# Altium schematic sheet GPS-GPRS Module.SchDoc
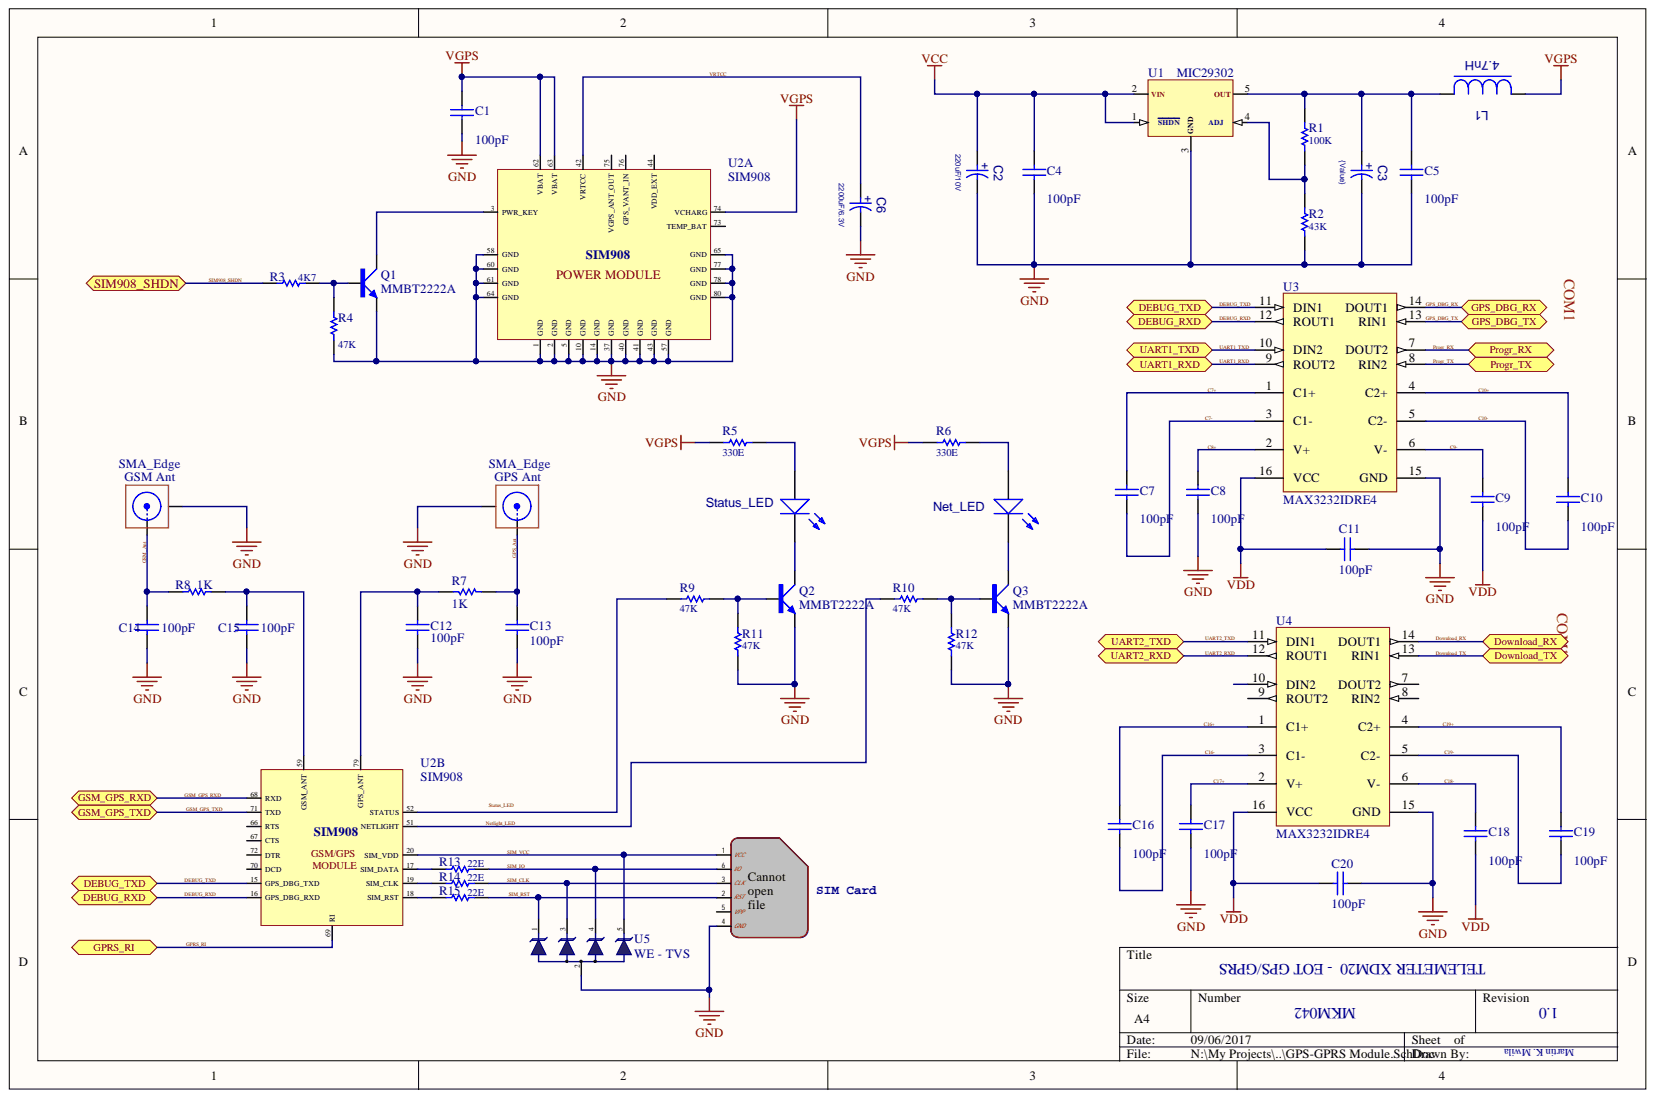
[diagram p0: GPS-GPRS Module.SchDoc]

## Parts
C1  value 100pF  [C-0805]  (hidden comment: Cap 0805)
C2  value 220uF/10V  [C-TANT#D - 220uF]  (hidden comment: Cap Tantalum TH)
C3  value {Value}  [470 uF 10x10.5 HT]  (hidden comment: Cap Elect_SMD 470 uF 10x10.5 HT)
C4  value 100pF  [C-0805]  (hidden comment: Cap 0805)
C5  value 100pF  [C-0805]  (hidden comment: Cap 0805)
C6  value 2200uF/6.3V  [2200 uF 10x10.5 HT]  (hidden comment: Cap Tantalum TH)
C7  value 100pF  [C-0805]  (hidden comment: Cap 0805)
C8  value 100pF  [C-0805]  (hidden comment: Cap 0805)
C9  value 100pF  [C-0805]  (hidden comment: Cap 0805)
C10  value 100pF  [C-0805]  (hidden comment: Cap 0805)
C11  value 100pF  [C-0805]  (hidden comment: Cap 0805)
C12  value 100pF  [CAP0603]  (hidden comment: Cap SMD 0603)
C13  value 100pF  [CAP0603]  (hidden comment: Cap SMD 0603)
C14  value 100pF  [CAP0603]  (hidden comment: Cap SMD 0603)
C15  value 100pF  [CAP0603]  (hidden comment: Cap SMD 0603)
C16  value 100pF  [C-0805]  (hidden comment: Cap 0805)
C17  value 100pF  [C-0805]  (hidden comment: Cap 0805)
C18  value 100pF  [C-0805]  (hidden comment: Cap 0805)
C19  value 100pF  [C-0805]  (hidden comment: Cap 0805)
C20  value 100pF  [C-0805]  (hidden comment: Cap 0805)
GPS_Ant  SMA_Edge  [SMA - Edge]
GSM_Ant  SMA_Edge  [SMA - Edge]
L1  value 4.7nH  [L-0805]  (hidden comment: Ferrire Bead-SMT 0805)
Net_LED  LED-0805_BL  [D-0805]  (value not drawn)
Q1  MMBT2222A  [SOT-23]
Q2  MMBT2222A  [SOT-23]
Q3  MMBT2222A  [SOT-23]
R1  value 100K  [R-0805 - 100K]  (hidden comment: Resistor - SMD 0805)
R2  value 43K  [R-0805 - 43K]  (hidden comment: Resistor - SMD 0805)
R3  value 4K7  [R-0805 -  4K7]  (hidden comment: Resistor - SMD 0805)
R4  value 47K  [R-0805 - 47K]  (hidden comment: Resistor - SMD 0805)
R5  value 330E  [R-0805 - 330E]  (hidden comment: Resistor - SMD 0805)
R6  value 330E  [R-0805 - 330E]  (hidden comment: Resistor - SMD 0805)
R7  value 1K  [R-0603]  (hidden comment: Resistor - SMD 0603)
R8  value 1K  [R-0603]  (hidden comment: Resistor - SMD 0603)
R9  value 47K  [R-0805 - 47K]  (hidden comment: Resistor - SMD 0805)
R10  value 47K  [R-0805 - 47K]  (hidden comment: Resistor - SMD 0805)
R11  value 47K  [R-0805 - 47K]  (hidden comment: Resistor - SMD 0805)
R12  value 47K  [R-0805 - 47K]  (hidden comment: Resistor - SMD 0805)
R13  value 22E  [R-0805 - 22E]  (hidden comment: Resistor - SMD 0805)
R14  value 22E  [R-0805 - 22E]  (hidden comment: Resistor - SMD 0805)
R15  value 22E  [R-0805 - 22E]  (hidden comment: Resistor - SMD 0805)
SIM_Card  CCM03-3002 R102  [SIM cARD]  (value not drawn)
Status_LED  LED-0805_BL  [D-0805]  (value not drawn)
U1  MIC29302  [MIC29302]
U2  SIM908  [SIM908]  (parts A,B)
U3  MAX3232IDRE4  [TI-D16_N]
U4  MAX3232IDRE4  [TI-D16_N]
U5  WE - TVS  [WE 82402374]

## Ports
DEBUG_RXD, DEBUG_TXD, Download_RX, Download_TX, GPRS_RI, GPS_DBG_RX, GPS_DBG_TX, GSM_GPS_RXD, GSM_GPS_TXD, Progr_RX, Progr_TX, SIM908_SHDN, UART1_RXD, UART1_TXD, UART2_RXD, UART2_TXD

## Nets
2: GPS_Ant.3 GPS_Ant.4 GPS_Ant.5 GPS_Ant.6 GSM_Ant.3 GSM_Ant.4 GSM_Ant.5 GSM_Ant.6
3: U1.6
GND: C1.1 C2.MINUS C3.MINUS C4.1 C5.1 C6.MINUS C8.1 C11.1 C12.1 C13.1 C14.1 C15.1 C17.1 C20.1 GPS_Ant.2 GSM_Ant.2 Q1.1 Q2.1 Q3.1 R2.1 R4.1 R11.2 R12.2 SIM_Card.4(GND) SIM_Card.7 SIM_Card.8 SIM_Card.9 SIM_Card.10 U1.3(GND) U2.1(GND) U2.2(GND) U2.5(GND) U2.10(GND) U2.14(GND) U2.37(GND) U2.40(GND) U2.41(GND) U2.43(GND) U2.57(GND) U2.58(GND) U2.60(GND) U2.61(GND) U2.64(GND) U2.65(GND) U2.77(GND) U2.78(GND) U2.80(GND) U3.15(GND) U4.15(GND) U5.2
VCC: C2.PLUS C4.2 U1.1(SHDN) U1.2(VIN)
VDD: C9.1 C11.2 C18.1 C20.2 U3.16(VCC) U4.16(VCC)
VGPS: C1.2 L1.1 R5.1 R6.1 U2.62(VBAT) U2.63(VBAT) U2.74(VCHARG)
C7+: C7.2 U3.1(C1+)
C7-: C7.1 U3.3(C1-)
C8+: C8.2 U3.2(V+)
C9-: C9.2 U3.6(V-)
C10+: C10.2 U3.4(C2+)
C10-: C10.1 U3.5(C2-)
C16+: C16.2 U4.1(C1+)
C16-: C16.1 U4.3(C1-)
C17+: C17.2 U4.2(V+)
C18-: C18.2 U4.6(V-)
C19+: C19.2 U4.4(C2+)
C19-: C19.1 U4.5(C2-)
DEBUG_RXD: U2.16(GPS_DBG_RXD) U3.12(ROUT1) ; port DEBUG_RXD
DEBUG_TXD: U2.15(GPS_DBG_TXD) U3.11(DIN1) ; port DEBUG_TXD
Download_RX: U4.14(DOUT1) ; port Download_RX
Download_TX: U4.13(RIN1) ; port Download_TX
GPRS_RI: U2.69(RI) ; port GPRS_RI
GPS_Ant: C13.2 GPS_Ant.1 R7.1
GPS_DBG_RX: U3.14(DOUT1) ; port GPS_DBG_RX
GPS_DBG_TX: U3.13(RIN1) ; port GPS_DBG_TX
GSM_Ant: C14.2 GSM_Ant.1 R8.1
GSM_GPS_RXD: U2.68(RXD) ; port GSM_GPS_RXD
GSM_GPS_TXD: U2.71(TXD) ; port GSM_GPS_TXD
Netlight_LED: R10.1 U2.51(NETLIGHT)
Progr_RX: U3.7(DOUT2) ; port Progr_RX
Progr_TX: U3.8(RIN2) ; port Progr_TX
SIM908_SHDN: R3.1 ; port SIM908_SHDN
SIM_CLK: R14.2 SIM_Card.3(CLK) U5.3
SIM_IO: R13.2 SIM_Card.6(I/O) U5.4
SIM_RST: R15.2 SIM_Card.2(RST) U5.1
SIM_VCC: SIM_Card.1(VCC) U2.20(SIM_VDD) U5.5
UART1_RXD: U3.9(ROUT2) ; port UART1_RXD
UART1_TXD: U3.10(DIN2) ; port UART1_TXD
UART2_RXD: U4.12(ROUT1) ; port UART2_RXD
UART2_TXD: U4.11(DIN1) ; port UART2_TXD
VRTCC: C6.PLUS U2.42(VRTCC)
NetC3_PLUS: C3.PLUS C5.2 L1.2 R1.2 U1.5(OUT)
NetC12_2: C12.2 R7.2 U2.79(GPS_ANT)
NetC15_2: C15.2 R8.2 U2.59(GSM_ANT)
NetNet_LED_1: Net_LED.1 Q3.3
NetNet_LED_2: Net_LED.2 R6.2
NetQ1_2: Q1.2 R3.2 R4.2
NetQ1_3: Q1.3 U2.3(PWR_KEY)
NetQ2_2: Q2.2 R9.2 R11.1
NetQ2_3: Q2.3 Status_LED.1
NetQ3_2: Q3.2 R10.2 R12.1
NetR1_1: R1.1 R2.2 U1.4(ADJ)
NetR5_2: R5.2 Status_LED.2
NetR9_1: R9.1 U2.52(STATUS)
NetR13_1: R13.1 U2.17(SIM_DATA)
NetR14_1: R14.1 U2.19(SIM_CLK)
NetR15_1: R15.1 U2.18(SIM_RST)
Status_LED:

Not connected: SIM_Card 5(VPP); U2 44(VDD_EXT) 66(RTS) 67(CTS) 70(DCD) 72(DTR) 73(TEMP_BAT) 75(VGPS_ANT_OUT) 76(GPS_VANT_IN); U4 7(DOUT2) 8(RIN2) 9(ROUT2) 10(DIN2)

## Library data of the parts
C1 C4 C5 C7-C11 C16-C20 = Cap 0805: Capacitor SMD; Component Kind: Standard; LatestRevisionDate: 17-Jul-2002; LatestRevisionNote: Re-released for DXP Platform.; Library Name: Capacitors.IntLib; PackageReference: C1206; Published: 8-Jun-2000; Publisher: Altium Limited
C2 = C-TANT 220uF: Tantalum Capacitor SMD; Component Kind: Standard; Library Name: Capacitors.IntLib
C3 = Cap Elect_SMD 470 uF 10x10.5 HT: SMD Electrolytic Capacitor; Component Kind: Standard; Library Name: Capacitors.IntLib
C6 = C-Electrolytic 2200uF_SMD: Aluminium Electrolytic Capacitor SMD; Component Kind: Standard; Library Name: Capacitors.IntLib
C12-C15 = Cap 0603: Capacitor SMD; Component Kind: Standard; LatestRevisionDate: 17-Jul-2002; LatestRevisionNote: Re-released for DXP Platform.; Library Name: Capacitors.IntLib; PackageReference: C1206; Published: 8-Jun-2000; Publisher: Altium Limited
GPS_Ant GSM_Ant = SMA_Edge: SMB Edge Connector; Component Kind: Standard; LatestRevisionDate: 17-Jul-2002; LatestRevisionNote: Re-released for DXP Platform.; Signal Integrity: Connector; Library Name: MKM Connectors.IntLib; Publisher: Altium Limited
L1 = Ferrire Bead-SMT 0805: Ferrire Bead -SMT 0805; Component Kind: Standard; Library Name: MKM Inductance_transformers.IntLib
Net_LED Status_LED = LED-0805_BL: Super Bright right angle; Assy: Loaded; CH: WL-08-2004; CR: VV; Vendor: Fairchild; Vendor P/N: QTLP610C-AG; ComponentHeight: 1.10mm; Component Kind: Standard; PCB3D: SW3dPS-LED_SMD_SML010L; Library Name: EOT.IntLib
Q1-Q3 = MMBT2222A: NPN Switching Transistor; Component Kind: Standard; ComponentLink1Description: Manufacturer Link; ComponentLink1URL: http://www.semiconductors.philips.com/; ComponentLink2Description: Datasheet; ComponentLink2URL: http://www.semiconductors.philips.com/acrobat/datasheets/MMBT2222A_2.pdf; DatasheetVersion: 11-Apr-2000; LatestRevisionDate: 12-Dec-2006; LatestRevisionNote: IPC-7351 Footprint Added.; Library Name: Transistors.IntLib; PackageDescription: 3-Pin SOT-23 Package 0.95 mm Pitch, 2.3 mm Lead Span; PackageReference: SOT23; PackageVersion: May-1999; Published: 17-Sep-2003; Signal Integrity: MMBT2222A; Simulation: QMMBT2222A; Publisher: Altium Limited
R1 = R0805- 100K: Component Kind: Standard; Description: Resistor - SMD 0805; LatestRevisionDate: 17-Jul-2002; LatestRevisionNote: Re-released for DXP Platform.; PackageReference: AXIAL-0.3; Published: 8-Jun-2000; Signal Integrity: Res; Simulation: RESISTOR; Library Name: MKM Resistors.IntLib; Publisher: Altium Limited
R2 = R0805- 43K: Component Kind: Standard; Description: Resistor - SMD 0805; LatestRevisionDate: 17-Jul-2002; LatestRevisionNote: Re-released for DXP Platform.; PackageReference: AXIAL-0.3; Published: 8-Jun-2000; Signal Integrity: Res; Simulation: RESISTOR; Library Name: MKM Resistors.IntLib; Publisher: Altium Limited
R3 = R0805- 4K7: Component Kind: Standard; Description: Resistor - SMD 0805; LatestRevisionDate: 17-Jul-2002; LatestRevisionNote: Re-released for DXP Platform.; PackageReference: AXIAL-0.3; Published: 8-Jun-2000; Signal Integrity: Res; Simulation: RESISTOR; Library Name: MKM Resistors.IntLib; Publisher: Altium Limited
R4 R9-R12 = R0805- 47K: Component Kind: Standard; Description: Resistor - SMD 0805; LatestRevisionDate: 17-Jul-2002; LatestRevisionNote: Re-released for DXP Platform.; PackageReference: AXIAL-0.3; Published: 8-Jun-2000; Signal Integrity: Res; Simulation: RESISTOR; Library Name: MKM Resistors.IntLib; Publisher: Altium Limited
R5 R6 = R0805- 330E: Component Kind: Standard; Description: Resistor - SMD 0805; LatestRevisionDate: 17-Jul-2002; LatestRevisionNote: Re-released for DXP Platform.; PackageReference: AXIAL-0.3; Published: 8-Jun-2000; Signal Integrity: Res; Simulation: RESISTOR; Library Name: MKM Resistors.IntLib; Publisher: Altium Limited
R7 R8 = R-0603: Component Kind: Standard; Description: Resistor - SMD 0603; LatestRevisionDate: 17-Jul-2002; LatestRevisionNote: Re-released for DXP Platform.; PackageReference: AXIAL-0.3; PCB3D: smt_resisto1206; Published: 8-Jun-2000; Signal Integrity: Res; Simulation: RESISTOR; Library Name: MKM Resistors.IntLib; Publisher: Altium Limited
R13-R15 = R0805- 22E: Component Kind: Standard; Description: Resistor - SMD 0805; LatestRevisionDate: 17-Jul-2002; LatestRevisionNote: Re-released for DXP Platform.; PackageReference: AXIAL-0.3; Published: 8-Jun-2000; Signal Integrity: Res; Simulation: RESISTOR; Library Name: MKM Resistors.IntLib; Publisher: Altium Limited
SIM_Card = SIM Card: <b>C707A SIMLOCK® 2.5 mm with wide solder tails</b><p> Source: <a href= "http://www.amphenol.info/downloads/C707_10M006_512_2.pdf">Data sheet</a>; Component Kind: Standard; Library Name: MKM Connectors.IntLib
U1 = MIC29302: 3 A Low Dropout Regulator with Micropower Quiescent Current and Shutdown, 3.8 to 15 V Vin, Adjustable Vout, 5-pin DPAK (Q-5), 0 to 125 degC; Manufacturer: Linear Technology; PartNumber: LT1529CQ; Discrete Pass Element: no; Dropout Voltage(V): 0.6; Iout(mA): 3000; Isupply(mA): 0.05; Number of Outputs: 1; Output Voltage: Adj; PSRR(dB): 62; Shutdown Current(uA): 15; Vin Min(V): 3.8; Vout Max(V): 14; Component Kind: Standard; ComponentLink1Description: Manufacturer URL; ComponentLink1URL: http://www.linear.com/; ComponentLink2Description: Datasheet; ComponentLink2URL: http://cds.linear.com/docs/en/datasheet/1529fb.pdf; ComponentLink3Description: Package Specification; ComponentLink3URL: http://cds.linear.com/docs/en/packaging/Q%20DD5%2005-08-1461%20Rev%20F.pdf; DatasheetVersion: Rev. B; Mounting Technology: Surface Mount; PackageDescription: 5-Pin Plastic DD Pak, Body 10.2235 x 8.89 mm, Pitch 1.702 mm; PackageReference: Q-5; PackageVersion: Rev. F, 09/2011; RoHS: FALSE; Supplier 1: Digi-Key; Supplier Part Number 1: LT1529CQ-ND; Vin Max(V): 15; Vout Min(V): 3.8; Library Name: MKM Voltage regulators.IntLib
U3 U4 = MAX3232IDRE4: 3-V to 5.5-V Multichannel RS-232 Line Driver / Receiver with ±15-kV ESD Protection, -40 to 85 degC, 16-Pin SOIC (D), Green (RoHS & no Sb/Br); Drivers Per Package: 2; ESD HBM(kV): +/-15; ICC(Max)(mA): 1; RS-232: TRUE; Supply Voltage(s)(V): 3.3 or 5.0; Component Kind: Standard; ComponentLink1Description: Manufacturer URL; ComponentLink1URL: www.ti.com; ComponentLink2Description: Datasheet; ComponentLink2URL: http://www.ti.com/general/docs/lit/getliterature.tsp?genericPartNumber=MAX3232&fileType=pdf; ComponentLink3Description: Package Specification; ComponentLink3URL: http://www.ti.com/litv/pdf/mpds178g; DatasheetVersion: SLLS410I; PackageDescription: 16-Pin Plastic Small Outline Integrated Circuit, 9.9 x 3.9 mm, Pitch 1.27 mm Pitch; PackageReference: D16; PackageVersion: revM, Jun-2011; Receivers Per Package: 2; Single Supply: TRUE; SubFamily: RS-232; Library Name: MKM Tech ICs.IntLib
U5 = WE-TVS: TVS Diode Array WE-TVS; Component Kind: Standard; Library Name: MKM Tech ICs.IntLib
U2 = SIM908: Component Kind: Standard; Library Name: MKM Tech ICs.IntLib
